# Altium schematic sheet ENGG2800-G16.SchDoc
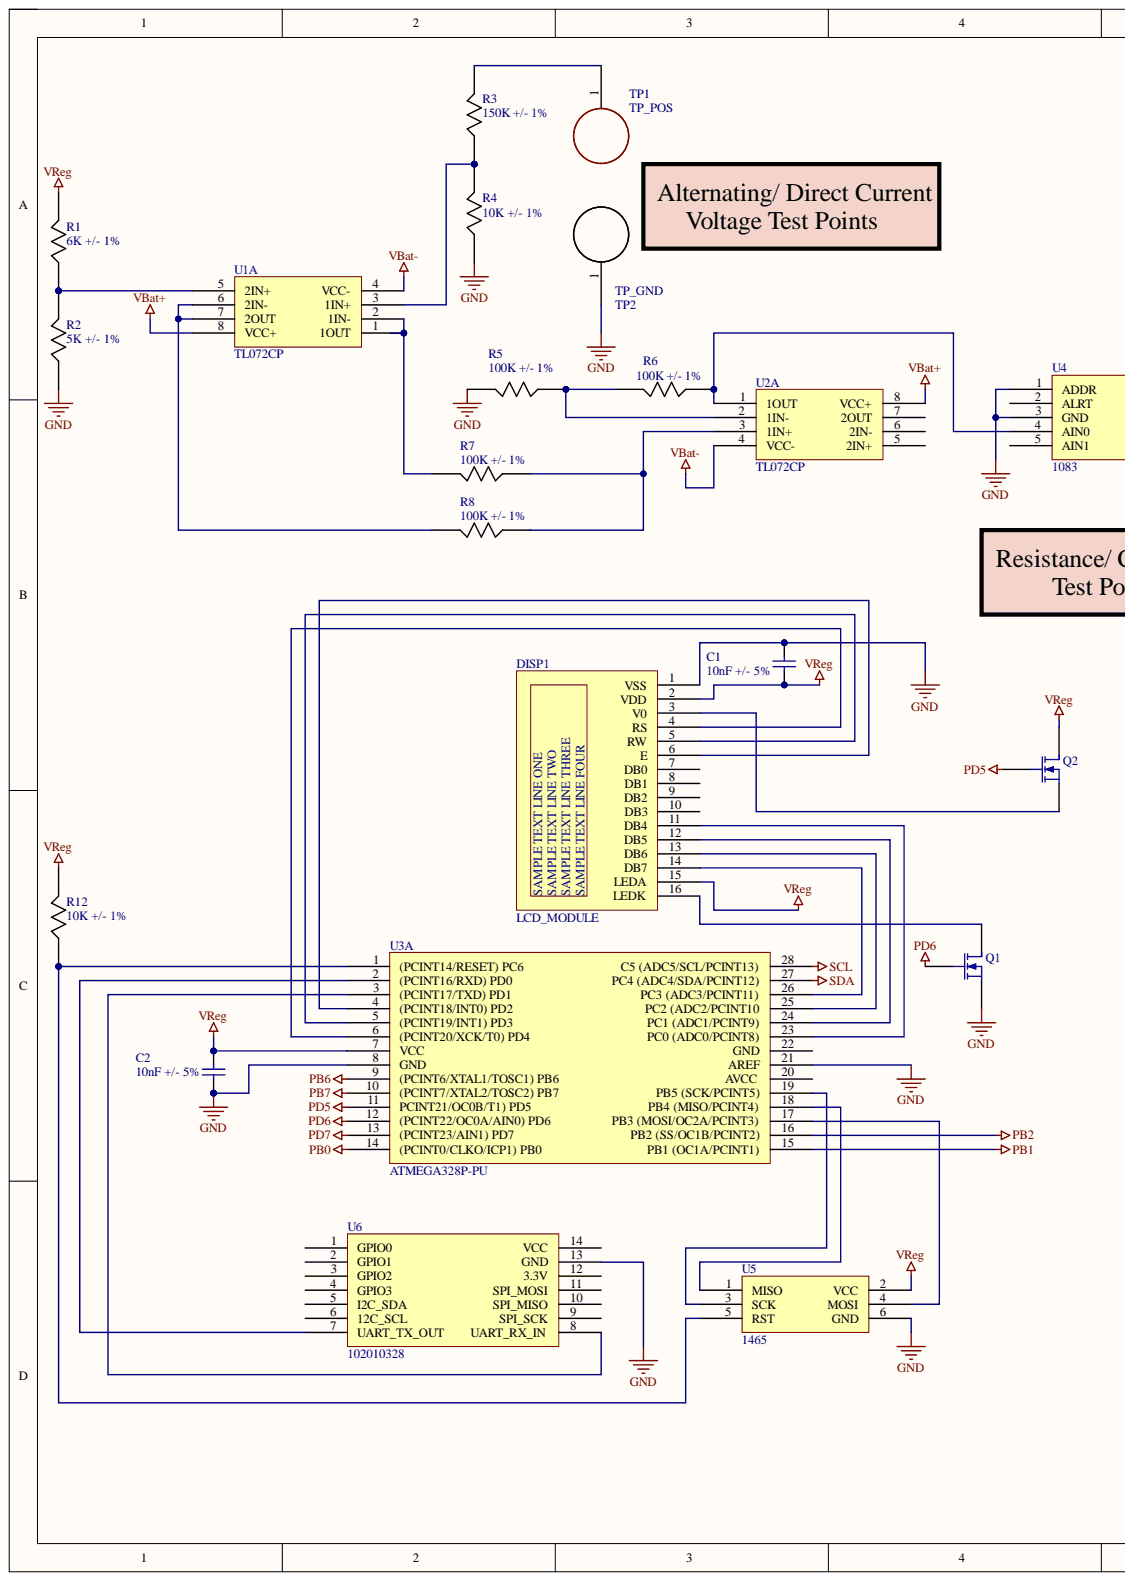
[diagram p0: ENGG2800-G16.SchDoc, left]
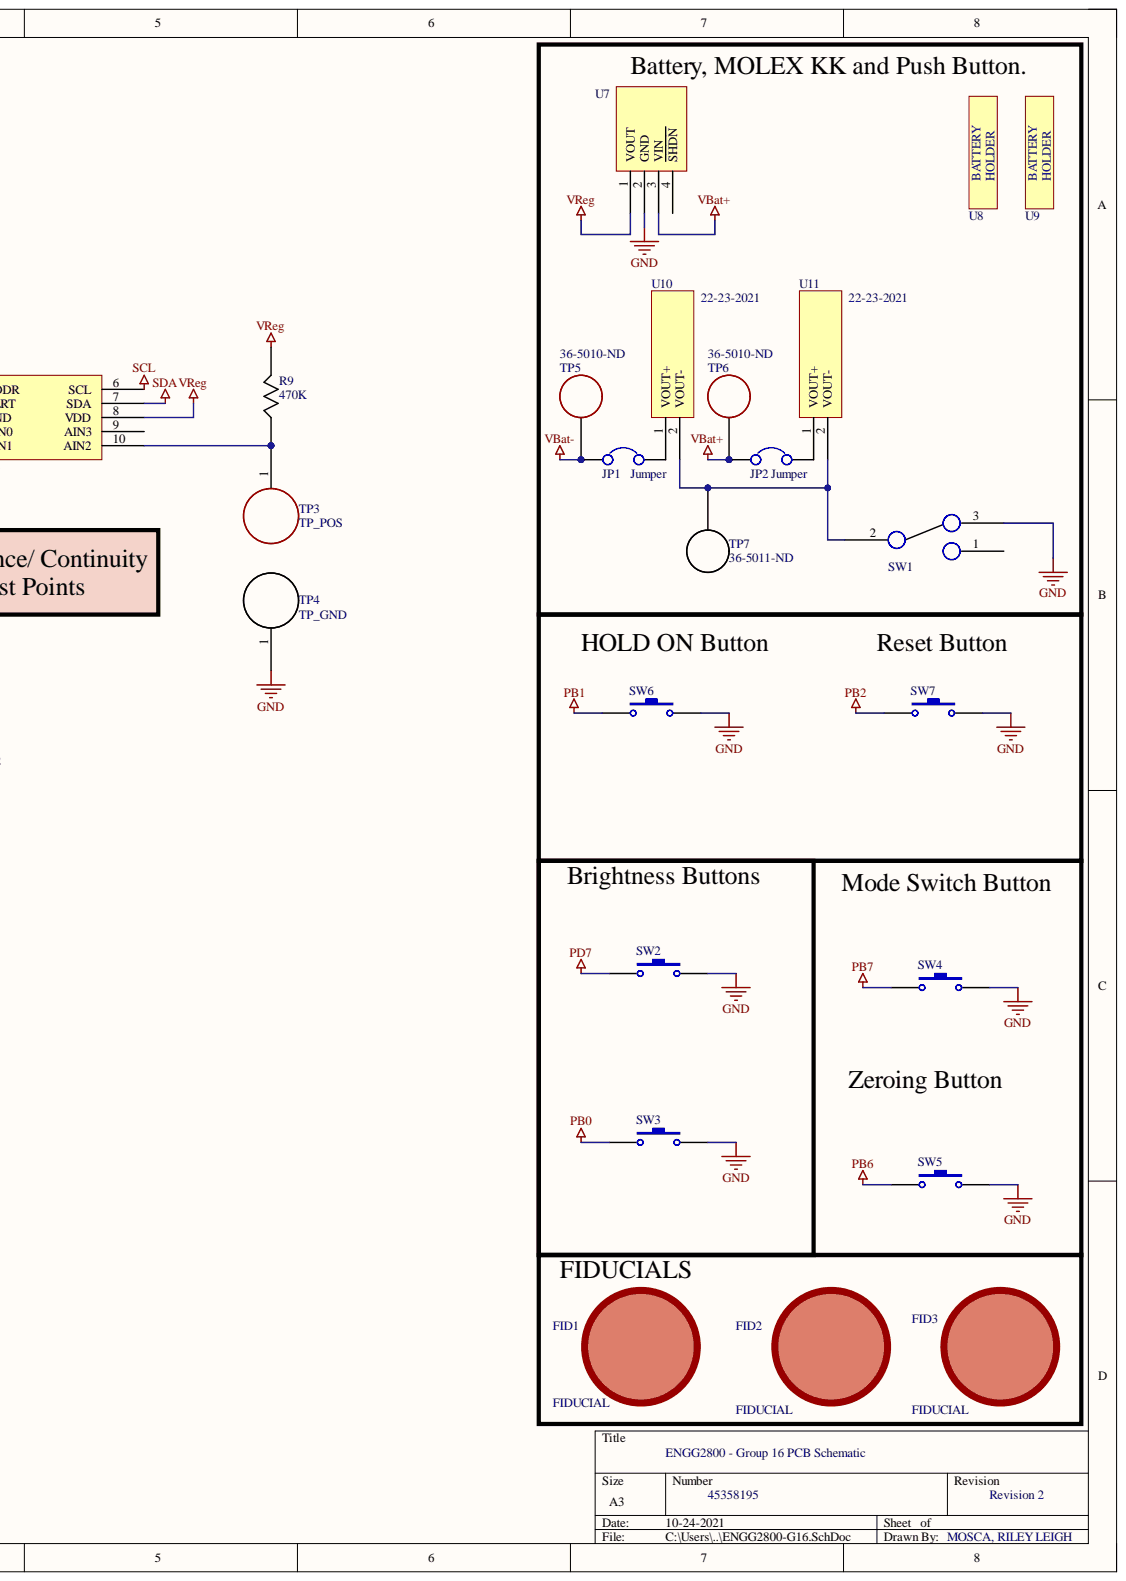
[diagram p1: ENGG2800-G16.SchDoc, right]

## Parts
C1  value 10nF +/- 5%  [RAD-0.3]  (hidden comment: Cap)
C2  value 10nF +/- 5%  [RAD-0.3]  (hidden comment: Cap)
DISP1  LCD_MODULE  [LCD]
FID1  FIDUCIAL  [FIDUCIAL]
FID2  FIDUCIAL  [FIDUCIAL]
FID3  FIDUCIAL  [FIDUCIAL]
JP1  Jumper  [Jumper]
JP2  Jumper  [Jumper]
Q1  MOSFET-N  [MOSSY]  (value not drawn)
Q2  MOSFET-N  [MOSSY]  (value not drawn)
R1  6K +/- 1%  [RESISTOR]
R2  5K +/- 1%  [RESISTOR]
R3  150K +/- 1%  [RESISTOR]
R4  10K +/- 1%  [RESISTOR]
R5  100K +/- 1%  [RESISTOR]
R6  100K +/- 1%  [RESISTOR]
R7  100K +/- 1%  [RESISTOR]
R8  100K +/- 1%  [RESISTOR]
R9  470K  [RESISTOR]
R12  10K +/- 1%  [RESISTOR]
SW1  SWITCH  [SWITCH]  (value not drawn)
SW2  SW-PB  [PUSH BTN]  (value not drawn)
SW3  SW-PB  [PUSH BTN]  (value not drawn)
SW4  SW-PB  [PUSH BTN]  (value not drawn)
SW5  (no value)  [PUSH BTN]
SW6  SW-PB  [PUSH BTN]  (value not drawn)
SW7  (no value)  [PUSH BTN]
TP1  TP_POS  [4MM BANAN_SOCKET]
TP2  TP_GND  [4MM BANAN_SOCKET]
TP3  TP_POS  [4MM BANAN_SOCKET]
TP4  TP_GND  [4MM BANAN_SOCKET]
TP5  5010  Supplier Part Number 1: 36-5010-ND  [TP1mm6]
TP6  5010  Supplier Part Number 1: 36-5010-ND  [TP1mm6]
TP7  5011  Supplier Part Number 1: 36-5011-ND  [TP1mm6]
U1  TL072CP  [TL072CP]  (part A)
U2  TL072CP  [TL072CP]  (part A)
U3  ATMEGA328P-PU  [ATMEGA 328P]  (part A)
U4  1083  [ADC]
U5  1465  [AVR ISP]
U6  102010328  [Seeduino]
U7  POLULU 5V Setp Up  [Regulator]  (value not drawn)
U8  BATTHOLDER  [BATTERY HOLDER]  (value not drawn)
U9  BATTHOLDER  [BATTERY HOLDER]  (value not drawn)
U10  22-23-2021  [MOLEX KK 0022232021]
U11  22-23-2021  [MOLEX KK 0022232021]

## Nets
GND: C1.2 C2.1 DISP1.1(VSS) Q1.3 R2.1 R4.1 R5.2 SW1.3 SW2.4 SW3.4 SW4.4 SW5.4 SW6.4 SW7.4 TP2.1 TP4.1 U3.8(GND) U3.21(AREF) U4.1(ADDR) U4.3(GND) U5.6(GND) U6.13(GND) U7.2(GND)
PB0: SW3.1 U3.14((PCINT0/CLKO/ICP1)_PB0)
PB1: SW6.1 U3.15(PB1_(OC1A/PCINT1))
PB2: SW7.1 U3.16(PB2_(SS/OC1B/PCINT2))
PB6: SW5.1 U3.9((PCINT6/XTAL1/TOSC1)_PB6)
PB7: SW4.1 U3.10((PCINT7/XTAL2/TOSC2)_PB7)
PD5: Q2.2 U3.11(PCINT21/OC0B/T1)_PD5)
PD6: Q1.2 U3.12((PCINT22/OC0A/AIN0)_PD6)
PD7: SW2.1 U3.13((PCINT23/AIN1)_PD7)
SCL: U3.28(C5_(ADC5/SCL/PCINT13)) U4.6(SCL)
SDA: U3.27(PC4_(ADC4/SDA/PCINT12)) U4.7(SDA)
VBat+: JP2.1 TP6.1 U1.8(VCC+) U2.8(VCC+) U7.3(VIN)
VBat-: JP1.1 TP5.1 U1.4(VCC-) U2.4(VCC-)
VReg: C1.1 C2.2 DISP1.2(VDD) DISP1.15(LEDA) Q2.1 R1.2 R9.2 R12.2 U3.7(VCC) U4.8(VDD) U5.2(VCC) U7.1(VOUT)
NetDISP1_3: DISP1.3(V0) Q2.3
NetDISP1_4: DISP1.4(RS) U3.6((PCINT20/XCK/T0)_PD4)
NetDISP1_5: DISP1.5(RW) U3.5((PCINT19/INT1)_PD3)
NetDISP1_6: DISP1.6(E) U3.4((PCINT18/INT0)_PD2)
NetDISP1_11: DISP1.11(DB4) U3.23(PC0_(ADC0/PCINT8))
NetDISP1_12: DISP1.12(DB5) U3.24(PC1_(ADC1/PCINT9))
NetDISP1_13: DISP1.13(DB6) U3.25(PC2_(ADC2/PCINT10)
NetDISP1_14: DISP1.14(DB7) U3.26(PC3_(ADC3/PCINT11))
NetDISP1_16: DISP1.16(LEDK) Q1.1
NetJP1_2: JP1.2 U10.1
NetJP2_2: JP2.2 U11.1
NetR1_1: R1.1 R2.2 U1.5(2IN+)
NetR3_1: R3.1 R4.2 U1.3(1IN+)
NetR3_2: R3.2 TP1.1
NetR5_1: R5.1 R6.2 U2.2(1IN-)
NetR6_1: R6.1 U2.1(1OUT) U4.4(AIN0)
NetR7_1: R7.1 R8.1 U2.3(1IN+)
NetR7_2: R7.2 U1.1(1OUT) U1.2(1IN-)
NetR8_2: R8.2 U1.6(2IN-) U1.7(2OUT)
NetR9_1: R9.1 TP3.1 U4.10(AIN2)
NetR12_1: R12.1 U3.1((PCINT14/RESET)_PC6) U5.5(RST)
NetSW1_2: SW1.2 TP7.1 U10.2 U11.2
NetU3_2: U3.2((PCINT16/RXD)_PD0) U6.7(UART_TX_OUT)
NetU3_3: U3.3((PCINT17/TXD)_PD1) U6.8(UART_RX_IN)
NetU3_17: U3.17(PB3_(MOSI/OC2A/PCINT3)) U5.4(MOSI)
NetU3_18: U3.18(PB4_(MISO/PCINT4)) U5.1(MISO)
NetU3_19: U3.19(PB5_(SCK/PCINT5)) U5.3(SCK)

Not connected: DISP1 7(DB0) 8(DB1) 9(DB2) 10(DB3); SW1 1; U2 5(2IN+) 6(2IN-) 7(2OUT); U3 20(AVCC) 22(GND); U4 2(ALRT) 5(AIN1) 9(AIN3); U6 1(GPIO0) 2(GPIO1) 3(GPIO2) 4(GPIO3) 5(I2C_SDA) 6(12C_SCL) 9(SPI_SCK) 10(SPI_MISO) 11(SPI_MOSI) 12(3.3V) 14(VCC); U7 4(SHDN)

## Library data of the parts
C1 C2 = Cap: Capacitor; Component Kind: Standard; LatestRevisionDate: 17-Jul-2002; LatestRevisionNote: Re-released for DXP Platform.; Library Name: Miscellaneous Devices.IntLib; PackageDescription: Radial Cap, Thru-Hole; 2 Leads; 0.3 in Pin Spacing; PackageReference: RAD-0.3; Published: 8-Jun-2000; Signal Integrity: Cap; Simulation: CAP; Publisher: Altium Limited
Q1 Q2 = MOSFET-N: N-Channel MOSFET; Component Kind: Standard; LatestRevisionDate: 17-Jul-2002; LatestRevisionNote: Re-released for DXP Platform.; Library Name: Miscellaneous Devices.IntLib; PackageDescription: TO, Flat Index; 3 In-Line, Axial Leads; Body Dia. 4.6mm; Lead Dia. 0.5 mm (max); PackageReference: E3; PackageVersion: 1995/1996; Published: 8-Jun-2000; Simulation: NMOS; Publisher: Altium Limited
R1-R9 R12 = RES: Component Kind: Standard; Library Name: ENGG2800_G16.SchLib
SW2-SW7 = SW-PB: Switch; Component Kind: Standard; LatestRevisionDate: 17-Jul-2002; LatestRevisionNote: Re-released for DXP Platform.; Library Name: Miscellaneous Devices.IntLib; PackageDescription: Switch; 2 Leads; PackageReference: SPST-2; Published: 24-Mar-1999; Publisher: Altium Limited
TP1 TP3 = TP_POS: Test Point MultiPurpose THM .445 L .125OD Heat Resistant Nylon 46 Red; Manufacturer: Keystone Electronics; Manufacturer Part Number: 5010; Body Material: Bronze,PBT; Hole Diameter: 1.6mm; Inside Diameter: 1.78mm; Number of Ports: 1; Plating: Silver; Category: Connectors; Color: Red; Component Kind: Standard; ComponentLink1Description: https://datasheet.ciiva.com/11744/0900766b8009b7c7-11744920.pdf?src-supplier=RSComponents; ComponentLink1URL: https://datasheet.ciiva.com/11744/0900766b8009b7c7-11744920.pdf?src-supplier=RSComponents; ComponentLink2Description: https://datasheet.ciiva.com/1454/1703983-1454833.pdf?src-supplier=Element14; ComponentLink2URL: https://datasheet.ciiva.com/1454/1703983-1454833.pdf?src-supplier=Element14; ComponentLink3Description: https://datasheet.ciiva.com/22631/1703983-22631113.pdf?src-supplier=Element14; ComponentLink3URL: https://datasheet.ciiva.com/22631/1703983-22631113.pdf?src-supplier=Element14; ComponentLink4Description: https://datasheet.ciiva.com/2917/5010-2917626.pdf?src-supplier=Digi-Key; ComponentLink4URL: https://datasheet.ciiva.com/2917/5010-2917626.pdf?src-supplier=Digi-Key; ComponentLink5Description: https://datasheet.ciiva.com/16506/m60-2p60-16506972.pdf?src-supplier=Future+Electronics; ComponentLink5URL: https://datasheet.ciiva.com/16506/m60-2p60-16506972.pdf?src-supplier=Future+Electronics; ComponentLink6Description: https://datasheet.ciiva.com/16883/70182825-16883886.pdf?src-supplier=Allied+Electronics; ComponentLink6URL: https://datasheet.ciiva.com/16883/70182825-16883886.pdf?src-supplier=Allied+Electronics; Contact Material: Bronze; Contact Plating: Silver,Tin; Flammability Rating: UL94 V-0; Height: 0.445inch; Housing Color: Red; Insulation Material: Nylon; Lead Free: Lead Free; Length: 11.3mm; Library Name: ENGG2800_G16.SchLib; Material: Nylon,Bronze,Silver; Mount: Through Hole,PCB; Orientation: Straight; Package Quantity: 100; Packaging: Bulk; Pricing 1: 1=0.42000, 25=0.34080, 100=0.30150, 250=0.28304, 500=0.25230, 1000=0.19884, 2500=0.18180, 5000=0.17044 (USD); REACH SVHC: No SVHC; RoHS: Yes; RoHS Compliant: Yes; Series: THM; Stock 1: 281924; Supplier 1: Digi-Key; Supplier Part Number 1: 36-5010-ND; Termination: Through Hole
TP2 TP4 = TP_GND: Test Point MultiPurpose THM .445 L .125OD Heat Resistant Nylon 46 Red; Manufacturer: Keystone Electronics; Manufacturer Part Number: 5010; Body Material: Bronze,PBT; Hole Diameter: 1.6mm; Inside Diameter: 1.78mm; Number of Ports: 1; Plating: Silver; Category: Connectors; Color: Red; Component Kind: Standard; ComponentLink1Description: https://datasheet.ciiva.com/11744/0900766b8009b7c7-11744920.pdf?src-supplier=RSComponents; ComponentLink1URL: https://datasheet.ciiva.com/11744/0900766b8009b7c7-11744920.pdf?src-supplier=RSComponents; ComponentLink2Description: https://datasheet.ciiva.com/1454/1703983-1454833.pdf?src-supplier=Element14; ComponentLink2URL: https://datasheet.ciiva.com/1454/1703983-1454833.pdf?src-supplier=Element14; ComponentLink3Description: https://datasheet.ciiva.com/22631/1703983-22631113.pdf?src-supplier=Element14; ComponentLink3URL: https://datasheet.ciiva.com/22631/1703983-22631113.pdf?src-supplier=Element14; ComponentLink4Description: https://datasheet.ciiva.com/2917/5010-2917626.pdf?src-supplier=Digi-Key; ComponentLink4URL: https://datasheet.ciiva.com/2917/5010-2917626.pdf?src-supplier=Digi-Key; ComponentLink5Description: https://datasheet.ciiva.com/16506/m60-2p60-16506972.pdf?src-supplier=Future+Electronics; ComponentLink5URL: https://datasheet.ciiva.com/16506/m60-2p60-16506972.pdf?src-supplier=Future+Electronics; ComponentLink6Description: https://datasheet.ciiva.com/16883/70182825-16883886.pdf?src-supplier=Allied+Electronics; ComponentLink6URL: https://datasheet.ciiva.com/16883/70182825-16883886.pdf?src-supplier=Allied+Electronics; Contact Material: Bronze; Contact Plating: Silver,Tin; Flammability Rating: UL94 V-0; Height: 0.445inch; Housing Color: Red; Insulation Material: Nylon; Lead Free: Lead Free; Length: 11.3mm; Library Name: ENGG2800_G16.SchLib; Material: Nylon,Bronze,Silver; Mount: Through Hole,PCB; Orientation: Straight; Package Quantity: 100; Packaging: Bulk; Pricing 1: 1=0.42000, 25=0.34080, 100=0.30150, 250=0.28304, 500=0.25230, 1000=0.19884, 2500=0.18180, 5000=0.17044 (USD); REACH SVHC: No SVHC; RoHS: Yes; RoHS Compliant: Yes; Series: THM; Stock 1: 281924; Supplier 1: Digi-Key; Supplier Part Number 1: 36-5010-ND; Termination: Through Hole
TP5 TP6 = Batt-TP-POS: Test Point MultiPurpose THM .445 L .125OD Heat Resistant Nylon 46 Red; Manufacturer: Keystone Electronics; Body Material: Bronze,PBT; Hole Diameter: 1.6mm; Inside Diameter: 1.78mm; Number of Ports: 1; Plating: Silver; Color: Red; Component Kind: Standard; ComponentLink1Description: https://datasheet.ciiva.com/11744/0900766b8009b7c7-11744920.pdf?src-supplier=RSComponents; ComponentLink1URL: https://datasheet.ciiva.com/11744/0900766b8009b7c7-11744920.pdf?src-supplier=RSComponents; ComponentLink2Description: https://datasheet.ciiva.com/2917/5010-2917626.pdf?src-supplier=Digi-Key; ComponentLink2URL: https://datasheet.ciiva.com/2917/5010-2917626.pdf?src-supplier=Digi-Key; ComponentLink3Description: https://datasheet.ciiva.com/16506/m60-2p60-16506972.pdf?src-supplier=Future+Electronics; ComponentLink3URL: https://datasheet.ciiva.com/16506/m60-2p60-16506972.pdf?src-supplier=Future+Electronics; ComponentLink4Description: https://datasheet.ciiva.com/22631/1703983-22631113.pdf?src-supplier=Element14; ComponentLink4URL: https://datasheet.ciiva.com/22631/1703983-22631113.pdf?src-supplier=Element14; ComponentLink5Description: https://datasheet.ciiva.com/16883/70182825-16883886.pdf?src-supplier=Allied+Electronics; ComponentLink5URL: https://datasheet.ciiva.com/16883/70182825-16883886.pdf?src-supplier=Allied+Electronics; ComponentLink6Description: https://datasheet.ciiva.com/1454/1703983-1454833.pdf?src-supplier=Element14; ComponentLink6URL: https://datasheet.ciiva.com/1454/1703983-1454833.pdf?src-supplier=Element14; Contact Material: Bronze; Contact Plating: Silver,Tin; Flammability Rating: UL94 V-0; Height: 0.445inch; Housing Color: Red; Insulation Material: Nylon; Lead Free: Lead Free; Length: 11.3mm; Library Name: ENGG2800_G16.SchLib; Manufacturer Part Number: 5010; Material: Nylon,Bronze,Silver; Mount: Through Hole,PCB; Orientation: Straight; Package Quantity: 100; Packaging: Bulk; Pricing 1: 1 = 0.42000, 25 = 0.34080, 100 = 0.30150, 250 = 0.28304, 500 = 0.25230, 1000 = 0.19884, 2500 = 0.18180, 5000 = 0.17044 (USD); REACH SVHC: No SVHC; RoHS: Yes; RoHS Compliant: Yes; Series: THM; Stock 1: 253363; Supplier 1: Digi-Key; Termination: Through Hole
TP7 = Batt-TP-GND: KEYSTONE - 5011 - PCB Test Point, Multipurpose, Black, Through Hole Mount, 1.6 mm, Phosphor Bronze; Manufacturer: Keystone Electronics; Body Material: PBT; Gender: Female; Hole Diameter: 1.6mm; Inside Diameter: 1.78mm; Number of Ports: 1; Color: Black; Component Kind: Standard; ComponentLink1Description: https://datasheet.ciiva.com/1454/1718230-1454834.pdf?src-supplier=Element14; ComponentLink1URL: https://datasheet.ciiva.com/1454/1718230-1454834.pdf?src-supplier=Element14; ComponentLink2Description: https://datasheet.ciiva.com/11744/0900766b8009b7c7-11744935.pdf?src-supplier=RSComponents; ComponentLink2URL: https://datasheet.ciiva.com/11744/0900766b8009b7c7-11744935.pdf?src-supplier=RSComponents; ComponentLink3Description: https://datasheet.ciiva.com/2917/5011-2917635.pdf?src-supplier=Digi-Key; ComponentLink3URL: https://datasheet.ciiva.com/2917/5011-2917635.pdf?src-supplier=Digi-Key; ComponentLink4Description: https://datasheet.ciiva.com/16351/m60-2p60-16351093.pdf?src-supplier=Future+Electronics; ComponentLink4URL: https://datasheet.ciiva.com/16351/m60-2p60-16351093.pdf?src-supplier=Future+Electronics; ComponentLink5Description: https://datasheet.ciiva.com/46412/1703983-46412138.pdf?src-supplier=Element14; ComponentLink5URL: https://datasheet.ciiva.com/46412/1703983-46412138.pdf?src-supplier=Element14; Contact Material: Bronze; Contact Plating: Silver,Tin; Flammability Rating: UL94 V-0; Housing Color: Black; Lead Free: Lead Free; Length: 11.3mm; Library Name: ENGG2800_G16.SchLib; Manufacturer Part Number: 5011; Material: Nylon,Bronze,Silver; Mount: PCB,Through Hole; Orientation: Straight; Package Quantity: 100; Packaging: Bulk; Pricing 1: 1 = 0.42000, 25 = 0.34080, 100 = 0.30150, 250 = 0.28304, 500 = 0.25230, 1000 = 0.19884, 2500 = 0.18180, 5000 = 0.17044 (USD); REACH SVHC: No SVHC; RoHS: Yes; RoHS Compliant: Yes; Series: THM; Stock 1: 719072; Supplier 1: Digi-Key
U1 U2 = TL072CP: IC, OP AMP, DUAL JFET, DIP8; Bandwidth:3MHz; No. of Amplifiers:2; Slew Rate:13V/ s; Supply Voltage Range:7V to 36V; Amplifier Case Style:DIP; No. of Pins:8; Operating Temperature Min:0 C; Operating Temperature Max:70 C; ;RoHS Compliant: Yes; Manufacturer: Texas Instruments; Bandwidth: 3MHz; Common Mode Rejection Ratio: 70dB; Dual Supply Voltage: 9V; Input Bias Current: 200pA; Input Offset Voltage (Vos): 3mV; Max Dual Supply Voltage: 18V; Max I/O Voltage: 10mV; Min Dual Supply Voltage: 3.5V; Nominal Supply Current: 5mA; Number of Amplifiers: 2; Operating Supply Current: 1.4mA; Slew Rate: 13V/µs; Voltage Gain: 106.02dB; Case/Package: P; Category: Amplifiers - Op Amps, Buffer, Instrumentation; Component Kind: Standard; ComponentLink1Description: https://datasheet.ciiva.com/7709/1764387-7709920.pdf?src-supplier=Element14; ComponentLink1URL: https://datasheet.ciiva.com/7709/1764387-7709920.pdf?src-supplier=Element14; ComponentLink2Description: https://datasheet.ciiva.com/1796/tl071a-1796082.pdf?src-supplier=Digi-Key; ComponentLink2URL: https://datasheet.ciiva.com/1796/tl071a-1796082.pdf?src-supplier=Digi-Key; ComponentLink3Description: https://datasheet.ciiva.com/2974/tl074-2974205.pdf?src-supplier=Avnet; ComponentLink3URL: https://datasheet.ciiva.com/2974/tl074-2974205.pdf?src-supplier=Avnet; ComponentLink4Description: https://datasheet.ciiva.com/26243/tl074-26243621.pdf?src-supplier=Avnet+Europe; ComponentLink4URL: https://datasheet.ciiva.com/26243/tl074-26243621.pdf?src-supplier=Avnet+Europe; Contact Plating: Gold; Gain Bandwidth Product: 3MHz; Height: 4.57mm; Lead Free: Lead Free; Length: 9.81mm; Library Name: ENGG2800_G16.SchLib; Manufacturer Part Number: TL072CP; Max Operating Temperature: 85°C; Max Supply Voltage: 18V; Min Operating Temperature: -40°C; Nominal Gain Bandwidth Product: 3MHz; Number of Channels: 2; Number of Circuits: 2; Number of Elements: 2; Number of Pins: 8; Package Quantity: 50; Packaging: Rail/Tube; Pricing 1: 1=1.02000, 10=0.91000, 25=0.86360, 100=0.70950, 250=0.66320, 500=0.58608, 1000=0.50925 (USD); Radiation Hardening: No; REACH SVHC: No SVHC; RoHS: Yes; RoHS Compliant: Yes; Supplier 1: Digi-Key; Supplier Part Number 1: 296-1775-5-ND; Unity Gain Bandwidth Product: 3MHz; Width: 6.35mm
U3 = ATMEGA328P-PU: IC MCU 8BIT 32KB FLASH 28DIP; Manufacturer: Microchip / Atmel; Core Architecture: AVR; Data Bus Width: 8b; Frequency: 20MHz; Interface: I2C,SPI,UART,USART,2-Wire; Max Supply Voltage: 5.5V; Min Supply Voltage: 1.8V; Number of ADC Channels: 6; Number of I2C Channels: 1; Number of I/Os: 23; Number of Timers/Counters: 3; Oscillator Type: Internal; Peripherals: Brown-out Detect/Reset,POR,PWM,WDT; RAM Size: 2KB; Watchdog Timer: Yes; Case/Package: PDIP; Category: Microcontrollers; Component Kind: Standard; ComponentLink1Description: https://datasheet.ciiva.com/19457/2139111-19457070.pdf?src-supplier=Jameco; ComponentLink1URL: https://datasheet.ciiva.com/19457/2139111-19457070.pdf?src-supplier=Jameco; ComponentLink2Description: https://datasheet.ciiva.com/1157/1684409-1157696.pdf?src-supplier=Element14; ComponentLink2URL: https://datasheet.ciiva.com/1157/1684409-1157696.pdf?src-supplier=Element14; ComponentLink3Description: https://datasheet.ciiva.com/20168/73-4283-20168380.pdf?src-supplier=Rapid+Electronics; ComponentLink3URL: https://datasheet.ciiva.com/20168/73-4283-20168380.pdf?src-supplier=Rapid+Electronics; ComponentLink4Description: https://datasheet.ciiva.com/29119/155197-da-01-en-microcontr-atmega328p-pu-8bit-pdip-28-29119593.pdf?src-supplier=Conrad; ComponentLink4URL: https://datasheet.ciiva.com/29119/155197-da-01-en-microcontr-atmega328p-pu-8bit-pdip-28-29119593.pdf?src-supplier=Conrad; ComponentLink5Description: https://datasheet.ciiva.com/26818/atmega328p-pu-26818578.pdf?src-supplier=IIIC; ComponentLink5URL: https://datasheet.ciiva.com/26818/atmega328p-pu-26818578.pdf?src-supplier=IIIC; ComponentLink6Description: https://datasheet.ciiva.com/15213/getdatasheetpartid-318533-15213133.pdf?src-supplier=Verical; ComponentLink6URL: https://datasheet.ciiva.com/15213/getdatasheetpartid-318533-15213133.pdf?src-supplier=Verical; Contact Plating: Tin,Matte; Height: 3.28mm; Lead Free: Lead Free; Length: 37.4mm; Library Name: ENGG2800_G16.SchLib; Manufacturer Part Number: ATMEGA328P-PU; Max Frequency: 20MHz; Max Operating Temperature: 85°C; Memory Size: 32KB; Memory Type: FLASH; Min Operating Temperature: -40°C; Mount: Through Hole; Number of Pins: 28; Number of Programmable I/O: 23; Number of PWM Channels: 6; Number of SPI Channels: 1; Package Quantity: 14; Packaging: Rail/Tube; Radiation Hardening: No; REACH SVHC: No SVHC; RoHS: Yes; RoHS Compliant: Yes; Series: AVR® ATmega; Supplier 1: Allied Electronics; Supplier Part Number 1: 70332157; Width: 6.76mm
U4 = 1083: EVAL BOARD ADS1115 16-BIT ADC; Manufacturer: Adafruit Industries; Evaluation Kit: Yes; Interface: I2C,Serial; Number of A/D Converters: 1; Number of Bits: 12; Sampling Rate: 3300S/s; Category: Integrated Circuits (ICs); Component Kind: Standard; ComponentLink1Description: http://www.alliedelec.com/m/d/e1a2ab321d3e1c71f82076eef7bd2507.pdf?src-supplier=Allied+Electronics; ComponentLink1URL: http://www.alliedelec.com/m/d/e1a2ab321d3e1c71f82076eef7bd2507.pdf?src-supplier=Allied+Electronics; ComponentLink2Description: https://cdn-learn.adafruit.com/downloads/pdf/adafruit-4-channel-adc-breakouts.pdf?src-supplier=Digi-Key; ComponentLink2URL: https://cdn-learn.adafruit.com/downloads/pdf/adafruit-4-channel-adc-breakouts.pdf?src-supplier=Digi-Key; Height: 0.25inch; Length: 4inch; Library Name: ENGG2800_G16.SchLib; Manufacturer Part Number: 1083; Pricing 1: 1=9.95000 (USD); RoHS: Yes; Stock 1: 63; Supplier 1: Digi-Key; Supplier Part Number 1: 1528-1014-ND; Weight: 0.25lb; Width: 2.5inch
U5 = 1465: Adafruit 6-pin AVR ISP Breadboard Adapter Mini Kit; Manufacturer: Adafruit Industries; Component Kind: Standard; ComponentLink1Description: https://media.digikey.com/pdf/Data%20Sheets/Adafruit%20PDFs/1465_Web.pdf?src-supplier=Digi-Key; ComponentLink1URL: https://media.digikey.com/pdf/Data%20Sheets/Adafruit%20PDFs/1465_Web.pdf?src-supplier=Digi-Key; Library Name: ENGG2800_G16.SchLib; Manufacturer Part Number: 1465; Pricing 1: 1=0.95000 (USD); RoHS: Yes; Stock 1: 59; Supplier 1: Digi-Key; Supplier Part Number 1: 1528-1189-ND
U6 = 102010328: Manufacturer: Seeed Studio; Category: Embedded Processors and Controllers; Component Kind: Standard; Library Name: ENGG2800_G16.SchLib; Manufacturer Part Number: 102010328; Pricing 1: 1=5.40000 (USD); RoHS: No; Stock 1: 3401; Supplier 1: Digi-Key; Supplier Part Number 1: 1597-102010328-ND
U7 = VREG: Component Kind: Standard; Library Name: ENGG2800_G16.SchLib
U8 U9 = BATTERY_HOLDER: Component Kind: Standard; Library Name: ENGG2800_G16.SchLib
U10 U11 = 22-23-2021: Connector Wire to Board Header 2 Position 2.54mm Solder Stra; Manufacturer: Molex; Approvals: UL,CSA; Contact Resistance: 10mR; Current Rating: 4A; Gender: Male; Glow Wire Compliant: Non-compliant; Insulation Resistance: 500GR; Number of Circuits: 2; Voltage Rating: 250V; Category: Headers and Wire Housings; Color: White; Component Kind: Standard; ComponentLink1Description: https://datasheet.ciiva.com/19454/232266-19454304.pdf?src-supplier=Jameco; ComponentLink1URL: https://datasheet.ciiva.com/19454/232266-19454304.pdf?src-supplier=Jameco; ComponentLink2Description: https://datasheet.ciiva.com/5150/0022232021-pcb-headers-227096-5150681.pdf?src-supplier=Mouser; ComponentLink2URL: https://datasheet.ciiva.com/5150/0022232021-pcb-headers-227096-5150681.pdf?src-supplier=Mouser; ComponentLink3Description: https://datasheet.ciiva.com/22683/1834442-22683561.pdf?src-supplier=Element14; ComponentLink3URL: https://datasheet.ciiva.com/22683/1834442-22683561.pdf?src-supplier=Element14; ComponentLink4Description: https://datasheet.ciiva.com/11746/0900766b80d8f4d1-11746058.pdf?src-supplier=RSComponents; ComponentLink4URL: https://datasheet.ciiva.com/11746/0900766b80d8f4d1-11746058.pdf?src-supplier=RSComponents; ComponentLink5Description: https://datasheet.ciiva.com/30253/114200022232021-pcb-headers-30253002.pdf?src-supplier=Onlinecomponents.com; ComponentLink5URL: https://datasheet.ciiva.com/30253/114200022232021-pcb-headers-30253002.pdf?src-supplier=Onlinecomponents.com; ComponentLink6Description: https://datasheet.ciiva.com/18453/70190606-18453638.pdf?src-supplier=Allied+Electronics; ComponentLink6URL: https://datasheet.ciiva.com/18453/70190606-18453638.pdf?src-supplier=Allied+Electronics; ComponentLink7Description: https://datasheet.ciiva.com/16658/0022232021-pcb-headers-16658167.pdf?src-supplier=Avnet+Europe; ComponentLink7URL: https://datasheet.ciiva.com/16658/0022232021-pcb-headers-16658167.pdf?src-supplier=Avnet+Europe; ComponentLink8Description: https://datasheet.ciiva.com/9468/0022232021-pcb-headers-9468476.pdf?src-supplier=Avnet; ComponentLink8URL: https://datasheet.ciiva.com/9468/0022232021-pcb-headers-9468476.pdf?src-supplier=Avnet; Contact Material: Brass; Contact Mating Length: 0.295inch; Contact Plating: Tin,Copper; Contact Type: Male Pin; Depth: 6.35mm; Fastening Type: Friction; Flammability Rating: UL94 V-0; Halogen Free: Low Halogen; Height: 10.67mm; Housing Color: White; Housing Material: Nylon; Lead Free: Lead Free; Length: 5.08mm; Library Name: ENGG2800_G16.SchLib; Manufacturer Part Number: 22-23-2021; Max Current Rating: 4A; Max Operating Temperature: 75°C; Min Operating Temperature: 0°C; Mount: Through Hole; Number of Contacts: 2; Number of Positions: 2; Number of Rows: 1; Orientation: Straight; Package Quantity: 3000; Packaging: Bulk; Pitch: 2.54mm; Pricing 1: 1=0.18000, 10=0.14300, 100=0.10270, 1000=0.08038, 5000=0.07145, 10000=0.06475 (USD); Radiation Hardening: No; REACH SVHC: Unknown; RoHS: Yes; RoHS Compliant: Yes; Series: KK; Stock 1: 33007; Supplier 1: Digi-Key; Supplier Part Number 1: 900-0022232021-ND; Termination: Solder; Voltage Rating (DC): 250V
DISP1 = LCD_MODULE: Component Kind: Standard; Library Name: ENGG2800_G16.SchLib
FID1-FID3 = FIDUCIAL: Component Kind: Standard; Library Name: ENGG2800_G16.SchLib
JP1 JP2 = Jumper: Component Kind: Standard; Library Name: ENGG2800_G16.SchLib
SW1 = SWITCH: Component Kind: Standard; Library Name: ENGG2800_G16.SchLib
